# Altium schematic sheet sdd_battery_charger.SchDoc(sdd_battery_charger)
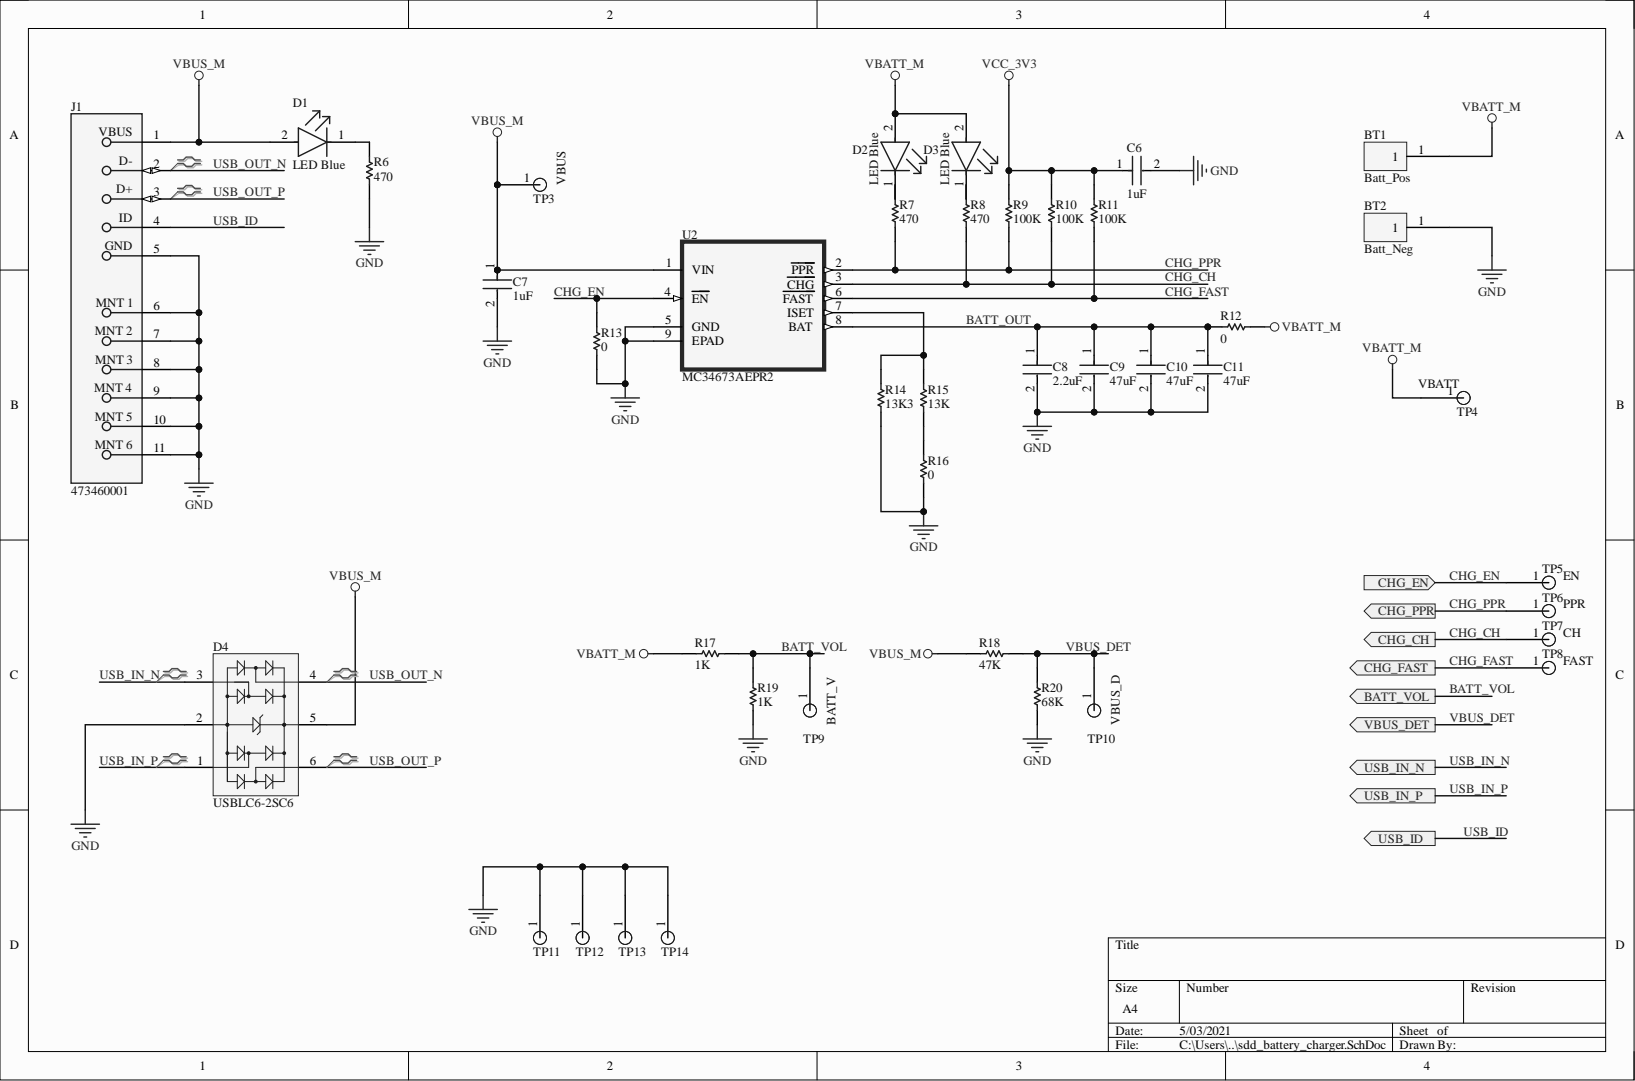
[diagram p0: sdd_battery_charger.SchDoc]

## Parts
BT1  Batt_Pos  [BattConn1]
BT2  Batt_Neg  [BattConn1]
C6  1uF  [FP-CL10B105KO8NNNC-MFG]
C7  1uF  [FP-CL10B105KO8NNNC-MFG]
C8  2.2uF  [FP-CL10-IPC_C]
C9  47uF  [FP-GRM188-0_2-IPC_B]
C10  47uF  [FP-GRM188-0_2-IPC_B]
C11  47uF  [FP-GRM188-0_2-IPC_B]
D1  LED Blue  Color: Blue  [FP-QBLP595-IB-MFG]
D2  LED Blue  Color: Blue  [FP-QBLP595-IB-MFG]
D3  LED Blue  Color: Blue  [FP-QBLP595-IB-MFG]
D4  USBLC6-2SC6  [STM-SOT23-6L_V]
J1  473460001  [FP-473460001-MFG]
R6  470  [RESC1608X55X30ML20T20]
R7  470  [RESC1608X55X30ML20T20]
R8  470  [RESC1608X55X30ML20T20]
R9  100K  [RESC1005X40X25ML05T05]
R10  100K  [RESC1005X40X25ML05T05]
R11  100K  [RESC1005X40X25ML05T05]
R12  0  [RESC1005X40X25NL05T10]
R13  0  [RESC1005X40X25NL05T10]
R14  13K3  [RESC1005X40X25ML05T05]
R15  13K  [RESC1005X40X25NL10T10]
R16  0  [RESC1005X40X25NL05T10]
R17  1K  [RESC1005X40X25LL05T10]
R18  47K  [RESC1005X40X25LL10T10]
R19  1K  [RESC1005X40X25LL05T10]
R20  68K  [RESC1005X40X25LL10T10]
TP3  VBUS  [Testpoint1]  (no BOM)
TP4  VBATT  [Testpoint1]  (no BOM)
TP5  EN  [Testpoint2]  (no BOM)
TP6  PPR  [Testpoint2]  (no BOM)
TP7  CH  [Testpoint2]  (no BOM)
TP8  FAST  [Testpoint2]  (no BOM)
TP9  BATT_V  [Testpoint1]  (no BOM)
TP10  VBUS_D  [Testpoint1]  (no BOM)
TP11  Testpoint2  [Testpoint2]  (value not drawn)  (no BOM)
TP12  Testpoint2  [Testpoint2]  (value not drawn)  (no BOM)
TP13  Testpoint2  [Testpoint2]  (value not drawn)  (no BOM)
TP14  Testpoint2  [Testpoint2]  (value not drawn)  (no BOM)
U2  MC34673AEPR2  [DFN200X300X65-9N]

## Ports
BATT_VOL, CHG_CH, CHG_EN, CHG_FAST, CHG_PPR, USB_ID, USB_IN_N, USB_IN_P, VBUS_DET

## Nets
GND: BT2.1 C6.2 C7.2 C8.2 C9.2 C10.2 C11.2 D4.2 J1.5 J1.6 J1.7 J1.8 J1.9 J1.10 J1.11 R6.2 R13.1 R14.1 R16.1 R19.2 R20.1 TP11.1 TP12.1 TP13.1 TP14.1 U2.5(GND) U2.9(EPAD)
VBATT_M: BT1.1 D2.2 D3.2 R12.1 R17.2 TP4.1
VBUS_M: C7.1 D1.2 D4.5 J1.1 R18.1 TP3.1 U2.1(VIN)
VCC_3V3: C6.1 R9.2 R10.2 R11.2
BATT_OUT: C8.1 C9.1 C10.1 C11.1 R12.2 U2.8(BAT)
BATT_VOL: R17.1 R19.1 TP9.1 ; port BATT_VOL
CHG_CH: R8.1 R10.1 TP7.1 U2.3(CHG) ; port CHG_CH
CHG_EN: R13.2 TP5.1 U2.4(EN) ; port CHG_EN
CHG_FAST: R11.1 TP8.1 U2.6(FAST) ; port CHG_FAST
CHG_PPR: R7.1 R9.1 TP6.1 U2.2(PPR) ; port CHG_PPR
USB_ID: J1.4 ; port USB_ID
USB_IN_N: D4.3 ; port USB_IN_N
USB_IN_P: D4.1 ; port USB_IN_P
USB_OUT_N: D4.4 J1.2
USB_OUT_P: D4.6 J1.3
VBUS_DET: R18.2 R20.2 TP10.1 ; port VBUS_DET
NetD1_1: D1.1 R6.1
NetD2_1: D2.1 R7.2
NetD3_1: D3.1 R8.2
NetR14_2: R14.2 R15.2 U2.7(ISET)
NetR15_1: R15.1 R16.2

## Library data of the parts
BT1 BT2 = BattConn1: Component Kind: Standard; Library Name: Batt_Conn.IntLib
C6 C7 = CMP-2000-06093-2: CAP CER 1UF 16V X7R 0603; Manufacturer: Samsung Electro-Mechanics America, Inc; Manufacturer Part Number: CL10B105KO8NNNC; Material: Ceramic; Temperature Coefficient: 15%; Tolerance: 10%; Automotive: No; Capacitance: 1uF; Category: Cap; Component Kind: Standard; ComponentLink2Description: Datasheet; ComponentLink2URL: http://www.samsungsem.com/global/front/downloadcms.do?path=%2F%2Fglobal%2F%2Fsupport%2F%2Fproduct-search%2F%2Fmlcc%2F%2F__icsFiles%2F%2Fafieldfile%2F%2F2019%2F%2F06%2F%2F18&fileName=CL10B105KO8NNNC-19.pdf; Device Class L1: Passive Components; Device Class L2: Capacitors; Device Class L3: Ceramic Capacitors; Digikey Description: CAP CER 1UF 16V X7R 0603; Height: 0.9mm; Ipc Land Pattern Name: CAPC16080X80; Lead Free: Yes; Library Name: Altium Content Vault; Octopart: https://octopart.com/cl10b105ko8nnnc-samsung-19018973; Package: CL10B105KO8NNNC; RoHS: TRUE; Temperature: -55°C to +125°C; Temperature Characteristic: X7R; Temperature Range High: +125°C; Temperature Range Low: -55°C; Value: 1uF; Voltage Rating: 16V
C8 = CMP-13271-002410-1: Cap Ceramic 2.2uF 6.3V X5R ±10% Pad SMD 0603 +85°C T/R; Capacitance: 2.2uF; Case Code (Imperial): 0603; Case Code (Metric): 1608; Case/Package: 0603; Component Kind: Standard; Contact Plating: Tin; Depth: 0.8mm; Dielectric: X5R; Height: 0.8mm; Lead Free: Lead Free; Length: 1.6mm; Library Name: Altium Content Vault; Max Operating Temperature: 85°C; Min Operating Temperature: -55°C; Mount: Surface Mount; Package Quantity: 1; Packaging: Tape and Reel; Radiation Hardening: No; Thickness: 0.035inch; Tolerance: 10%; Voltage: 6.3V; Voltage Rating: 6.3V; Voltage Rating (DC): 6.3V; Width: 0.031inch
C9-C11 = CMP-2000-05444-2: Chip Multilayer Ceramic Capacitors for General Purpose, 0603, 47uF, X5R, 15%, 20%, 6.3V; Capacitance: 47uF; Case Code (Imperial): 0603; Case Code (Metric): 1608; Case/Package: 0603; Component Kind: Standard; Dielectric: X5R; Length: 0.063inch; Library Name: Altium Content Vault; Max Operating Temperature: 85°C; Min Operating Temperature: -55°C; Mount: Surface Mount; Packaging: Tape and Reel; Thickness: 0.039inch; Tolerance: 20%; Voltage Rating: 6.3V; Voltage Rating (DC): 6.3V; Width: 0.031inch
D1-D3 = CMP-113271-000002-1: LED BLUE CLEAR 0402 SMD; Dominant Wavelength: 465nm; Forward Voltage: 3.1V; Lens Color: Colorless; Lens Size: 0.5mm; Lens Style: Flat; Luminous Intensity: 85mcd; Test Current: 20mA; Viewing Angle: 140°; Case Code (Imperial): 0402; Case Code (Metric): 1005; Case/Package: 0402; Component Kind: Standard; Height: 0.6mm; Length: 1mm; Lens Transparency: Clear; Library Name: Altium Content Vault; Mount: Surface Mount; Packaging: Tape and Reel; Width: 0.5mm
D4 = CMP-2000-05378-1: Very low capacitance ESD protection, 3.5 pF, -40 to 125 degC, 6-Pin SOT23, RoHS, Tape and Reel; Manufacturer: STMicroelectronics; Capacitance Value: 3.5pF; Clamping Voltage: 17V; Configuration: Dual; Direction Type: Uni-Directional; Leakage Current: 0.15uA; Number of Elements: 1; Peak Pulse Current: 5A; Product Depth (mm): 1.75mm; Product Height (mm): 1.3mm; Product Length (mm): 3.05mm; Rad Hardened: No; Reverse Breakdown Voltage: 6V; Reverse Stand-off Voltage: 5V; Suppressor Type: DiodeArrays; Test Current (It): 1mA; Clamping Voltage (Max): 17V; Component Kind: Standard; Datasheet URL: http://www.st.com/st-web-ui/static/active/en/resource/technical/document/datasheet/CD00050750.pdf; Datasheet Version: Rev. 5, 10/2011; Leakage Current (Max): 0.15uA; Library Name: Altium Content Vault; Manufacturer URL: http://www.st.com/; Mounting: SurfaceMount; Mounting Style: SurfaceMount; Operating Temp Range: -40Cto125C; Operating Temperature Classification: Automotive; Operating Temperature Max Deg. C: 125C; Operating Temperature Min Deg. C: -40C; Package / Case: SOT-23; Package Description: 6-Pin Small Outline Transistor, Body 2.925 x 1.625 mm, Pitch 0.95 mm; Package Reference: SOT23-6L; Packaging: TapeandReel; Pin Count: 6; Polarity: Uni-Directional; Working Voltage: 5V; Working Voltage (Max): 5V
J1 = CMP-2000-05246-2: CONN RCPT USB2.0 MICRO B SMD R/A; Manufacturer: Molex; Conn Orientation: Right Angle; Current Rating: 1.8A; Is Female: yes; Number Of Contacts: 5; Pitch: 0.65mm; Shielding: true; Voltage Rating: 30V; Automotive: No; Category: Conn; Component Kind: Standard; ComponentLink2Description: Datasheet; ComponentLink2URL: https://www.molex.com/webdocs/datasheets/pdf/en-us/0473460001_IO_CONNECTORS.pdf; Contact Material: Gold,Nickel; Device Class L1: Connectors; Device Class L2: USB Connectors; Device Class L3: unset; Digikey Description: CONN RCPT USB2.0 MICRO B SMD R/A; Footprint Url: https://www.molex.com/pdm_docs/sd/473460001_sd.pdf; Height: 2.945mm; Lead Free: Yes; Library Name: Altium Content Vault; Manufacturer Part Number: 473460001; Octopart: https://octopart.com/47346-0001-molex-7164928; Package: 0; RoHS: TRUE; Temperature: -30°C to +85°C; Temperature Range High: +85°C; Temperature Range Low: -30°C; Usb Standard: USB 2.0
R6-R8 = CMP-2000-00071-1: Manufacturer: Panasonic; Part Number: ERA3AEB471V; Component Kind: Standard; Library Name: Altium Content Vault
R9-R11 = CMP-1011-00736-2: Manufacturer: Vishay Dale; Part Number: CRCW0402100KFKTD; Component Kind: Standard; Library Name: Altium Content Vault
R12 R13 R16 = CMP-1011-00001-2: Manufacturer: Yageo / Phycomp; Part Number: RC0402JR-070RL; Component Kind: Standard; Library Name: Altium Content Vault
R14 = CMP-2002-08197-1: Manufacturer: Vishay Dale; Part Number: CRCW040213K3FKED; Component Kind: Standard; Library Name: Altium Content Vault
R15 = CMP-2002-05782-1: Manufacturer: Panasonic; Part Number: ERA-2AEB133X; Component Kind: Standard; Library Name: Altium Content Vault
R17 R19 = CMP-2002-03045-1: Manufacturer: Vishay Dale; Part Number: CRCW04021K00FKEDHP; Component Kind: Standard; Library Name: Altium Content Vault
R18 = CMP-2002-05738-1: Manufacturer: TE Connectivity / AMP; Part Number: CRG0402F47K; Component Kind: Standard; Library Name: Altium Content Vault
R20 = CMP-2002-05750-1: Manufacturer: TE Connectivity / AMP; Part Number: CRG0402F68K; Component Kind: Standard; Library Name: Altium Content Vault
TP3 TP4 TP9 TP10 = Testpoint1: Library Name: TestPoint.IntLib
TP5-TP8 = Testpoint2: Library Name: TestPoint_Round.IntLib
U2 = MC34673AEPR2: Charger IC Lithium Ion/Polymer 8-UDFN _3x2_; MF: NXP USA; Availability: Unavailable; Component Kind: Standard; Library Name: MC34673AEPR2.IntLib; MP: MC34673AEPR2; Package: UDFN-8 NXP Semiconductors; Price: None; SnapEDA_Link: https://www.snapeda.com/parts/MC34673AEPR2/NXP%20USA%20Inc./view-part/502210/?ref=snap
TP11-TP14 = Testpoint2: Library Name: TestPoint_Round.IntLib
